# Altium schematic sheet usb.SchDoc(usb)
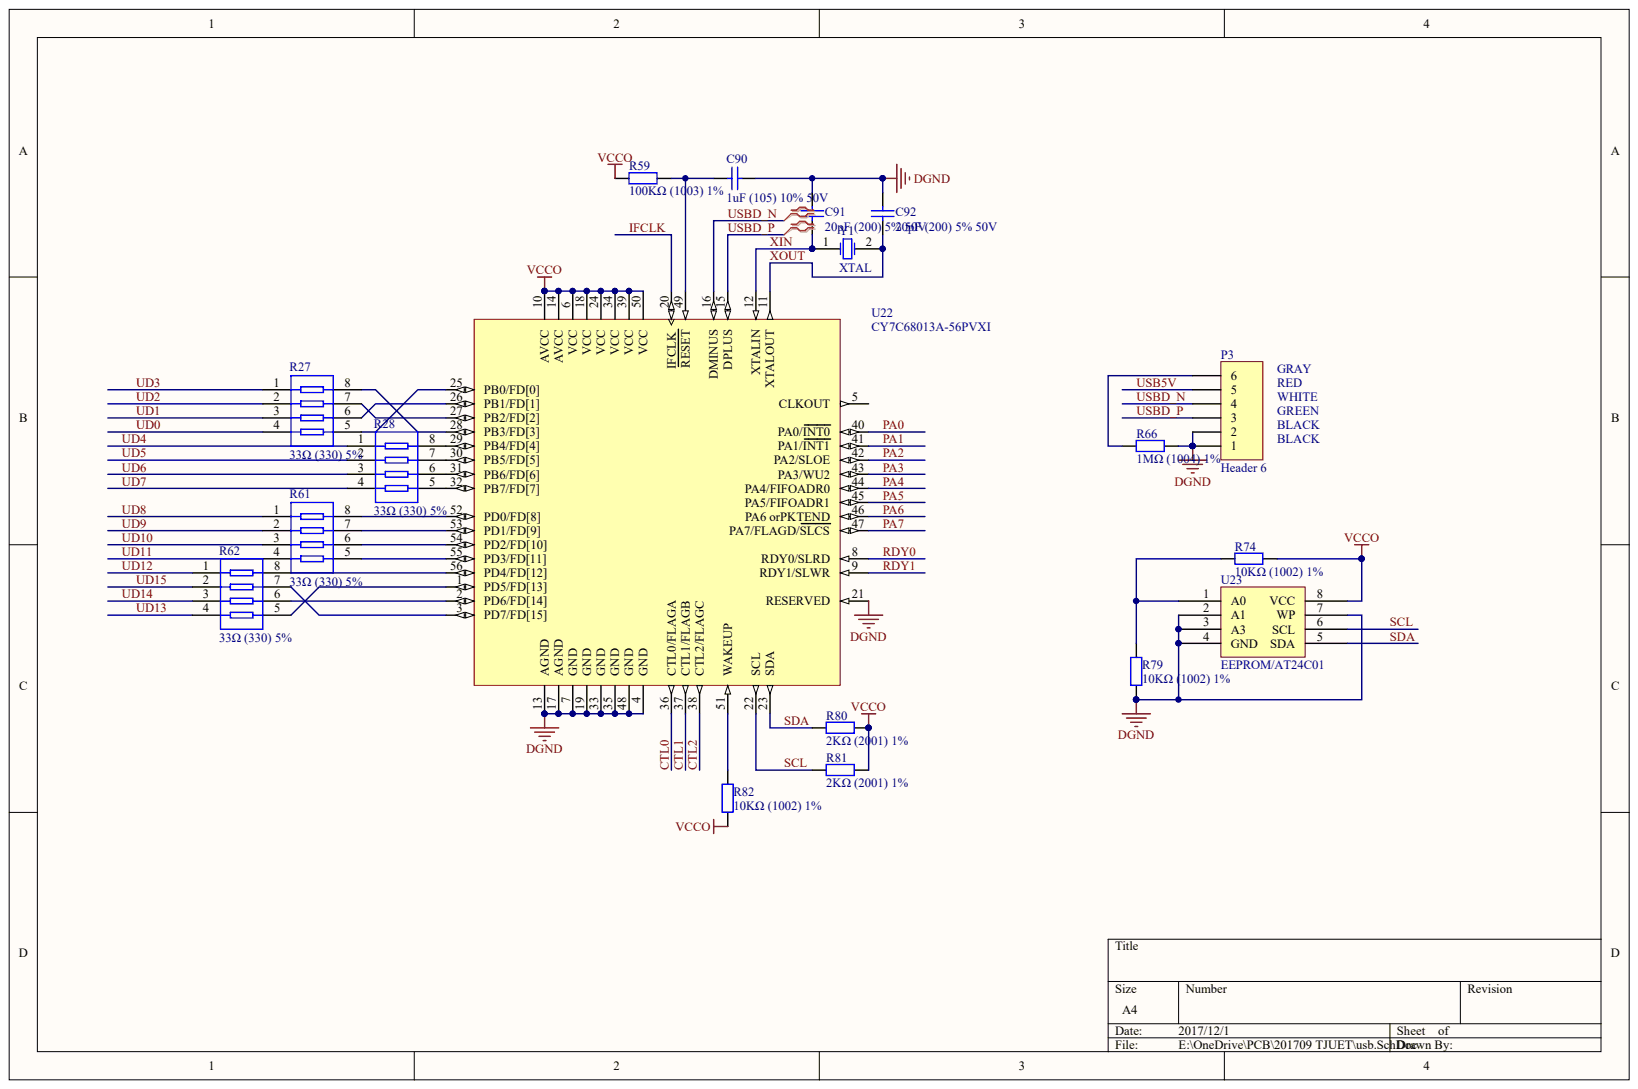
[diagram p0: usb.SchDoc]

## Parts
C90  1uF (105) 10% 50V
C91  20pF (200) 5% 50V
C92  20pF (200) 5% 50V
P3  Header 6
R27  33Ω (330) ±5%
R28  33Ω (330) ±5%
R59  100KΩ (1003) ±1%
R61  33Ω (330) ±5%
R62  33Ω (330) ±5%
R66  1MΩ (1004) ±1%
R74  10KΩ (1002) ±1%
R79  10KΩ (1002) ±1%
R80  2KΩ (2001) ±1%
R81  2KΩ (2001) ±1%
R82  10KΩ (1002) ±1%
U22  CY7C68013A-56PVXI
U23  EEPROM/AT24C01
Y1  XTAL

## Nets
DGND: C90.2 C91.2 C92.2 P3.1 P3.2 R66.1 R79.2 U22.4(GND) U22.7(GND) U22.13(AGND) U22.17(AGND) U22.19(GND) U22.21(RESERVED) U22.33(GND) U22.35(GND) U22.48(GND) U23.2(A1) U23.3(A3) U23.4(GND) U23.7(WP)
VCCO: R59.1 R74.1 R80.2 R81.2 R82.1 U22.6(VCC) U22.10(AVCC) U22.14(AVCC) U22.18(VCC) U22.24(VCC) U22.34(VCC) U22.39(VCC) U22.50(VCC) U23.8(VCC)
CTL0: U22.36(CTL0/FLAGA)
CTL1: U22.37(CTL1/FLAGB)
CTL2: U22.38(CTL2/FLAGC)
IFCLK: U22.20(IFCLK)
PA0: U22.40(PA0/INT0)
PA1: U22.41(PA1/INT1)
PA2: U22.42(PA2/SLOE)
PA3: U22.43(PA3/WU2)
PA4: U22.44(PA4/FIFOADR0)
PA5: U22.45(PA5/FIFOADR1)
PA6: U22.46(PA6_orPKTEND)
PA7: U22.47(PA7/FLAGD/SLCS)
RDY0: U22.8(RDY0/SLRD)
RDY1: U22.9(RDY1/SLWR)
SCL: R81.1 U22.22(SCL) U23.6(SCL)
SDA: R80.1 U22.23(SDA) U23.5(SDA)
UD0: R27.4
UD1: R27.3
UD2: R27.2
UD3: R27.1
UD4: R28.1
UD5: R28.2
UD6: R28.3
UD7: R28.4
UD8: R61.1
UD9: R61.2
UD10: R61.3
UD11: R61.4
UD12: R62.1
UD13: R62.4
UD14: R62.3
UD15: R62.2
USB5V: P3.5
USBD_N: P3.4 U22.16(DMINUS)
USBD_P: P3.3 U22.15(DPLUS)
XIN: C91.1 U22.12(XTALIN) Y1.1
XOUT: C92.1 U22.11(XTALOUT) Y1.2
NetC90_1: C90.1 R59.2 U22.49(RESET)
NetP3_6: P3.6 R66.2
NetR27_5: R27.5 U22.25(PB0/FD[0])
NetR27_6: R27.6 U22.26(PB1/FD[1])
NetR27_7: R27.7 U22.27(PB2/FD[2])
NetR27_8: R27.8 U22.28(PB3/FD[3])
NetR28_5: R28.5 U22.32(PB7/FD[7])
NetR28_6: R28.6 U22.31(PB6/FD[6])
NetR28_7: R28.7 U22.30(PB5/FD[5])
NetR28_8: R28.8 U22.29(PB4/FD[4])
NetR61_5: R61.5 U22.55(PD3/FD[11])
NetR61_6: R61.6 U22.54(PD2/FD[10])
NetR61_7: R61.7 U22.53(PD1/FD[9])
NetR61_8: R61.8 U22.52(PD0/FD[8])
NetR62_5: R62.5 U22.1(PD5/FD[13])
NetR62_6: R62.6 U22.2(PD6/FD[14])
NetR62_7: R62.7 U22.3(PD7/FD[15])
NetR62_8: R62.8 U22.56(PD4/FD[12])
NetR74_2: R74.2 R79.1 U23.1(A0)
NetR82_2: R82.2 U22.51(WAKEUP)

Not connected: U22 5(CLKOUT)

## Library data of the parts
C90-C92 P3 R27 R28 R59 R61 R62 R66 R74 R79-R82 U22 U23 Y1 = (no symbol name)
  C90: ComponentLink1Description: 供应商链接; ComponentLink1URL: http://www.szlcsc.com/product/details_29074.html; Package: 0805; Supplier: LC; SuppliersPartNumber: C28323
  C91 C92: ComponentLink1Description: 供应商链接; ComponentLink1URL: http://www.szlcsc.com/product/details_2150.html; Package: 0805; Supplier: LC; SuppliersPartNumber: C1798
  P3: LatestRevisionDate: 17-Jul-2002; LatestRevisionNote: Re-released for DXP Platform.
  R27 R28 R61 R62: ComponentLink1Description: 供应商链接; ComponentLink1URL: http://www.szlcsc.com/product/details_26244.html; Package: 0402*4; Supplier: LC; SuppliersPartNumber: C25501
  R59: ComponentLink1Description: 供应商链接; ComponentLink1URL: http://www.szlcsc.com/product/details_18095.html; Package: 0805; Supplier: LC; SuppliersPartNumber: C17407
  R66: ComponentLink1Description: 供应商链接; ComponentLink1URL: http://www.szlcsc.com/product/details_18202.html; Package: 0805; Supplier: LC; SuppliersPartNumber: C17514
  R74 R79 R82: ComponentLink1Description: 供应商链接; ComponentLink1URL: http://www.szlcsc.com/product/details_18102.html; Package: 0805; Supplier: LC; SuppliersPartNumber: C17414
  R80 R81: ComponentLink1Description: 供应商链接; ComponentLink1URL: http://www.szlcsc.com/product/details_18292.html; Package: 0805; Supplier: LC; SuppliersPartNumber: C17604
  U22: Published: 16-Dec-2005; DatasheetVersion: rev.J, Sep-2005; PackageVersion: Feb-2005; PackageReference: O56; PackageDescription: SSOP; 56 Leads; Body Width 7.5 mm; Pitch 0.635 mm; Code_JEDEC: MO-118-AB; Code_IPC: SSO56; ComponentLink1Description: Manufacturer Link; ComponentLink1URL: http://www.cypress.com/portal/server.pt; ComponentLink2Description: Datasheet; ComponentLink2URL: http://www.cypress.com/portal/server.pt/gateway/PTARGS_0_2_1524_209_259_43/http%3B/sjapp20.mis.cypress.com%3B7001/publishedcontent/publish/design_resources/datasheets/contents/cy7c68013a_5.pdf
  U23: ComponentLink1Description: 供应商链接; ComponentLink1URL: http://www.szlcsc.com/product/details_6947.html; Package: SOIC-8_150mil; Supplier: LC; SuppliersPartNumber: C6482
  Y1: Published: 8-Jun-2000; LatestRevisionDate: 17-Jul-2002; LatestRevisionNote: Re-released for DXP Platform.; PackageReference: R38; PackageDescription: Crystal, Thru-Hole; 2 Leads; Body 3.1 x 8.2 mm (Dia.xH)
  P3 U22 Y1: Publisher: Altium Limited
